# Altium schematic sheet HAC-NBC-PV1.0.SchDoc
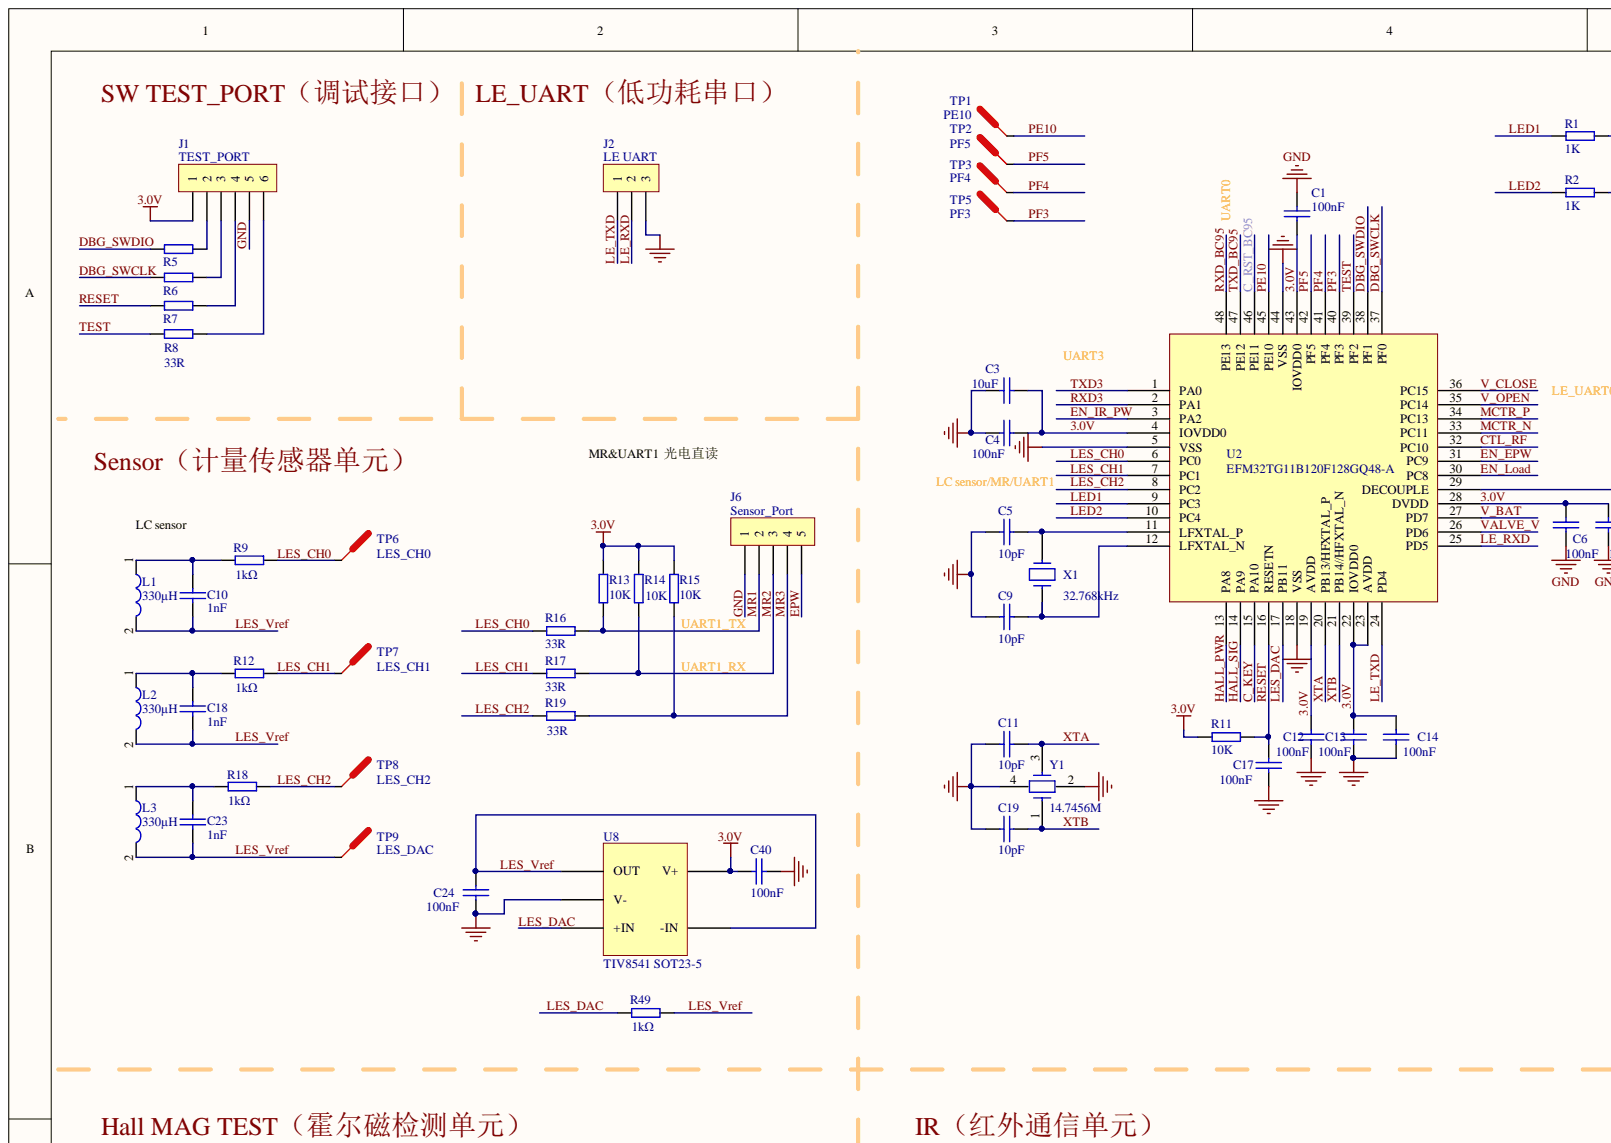
[diagram p0: HAC-NBC-PV1.0.SchDoc, top left]
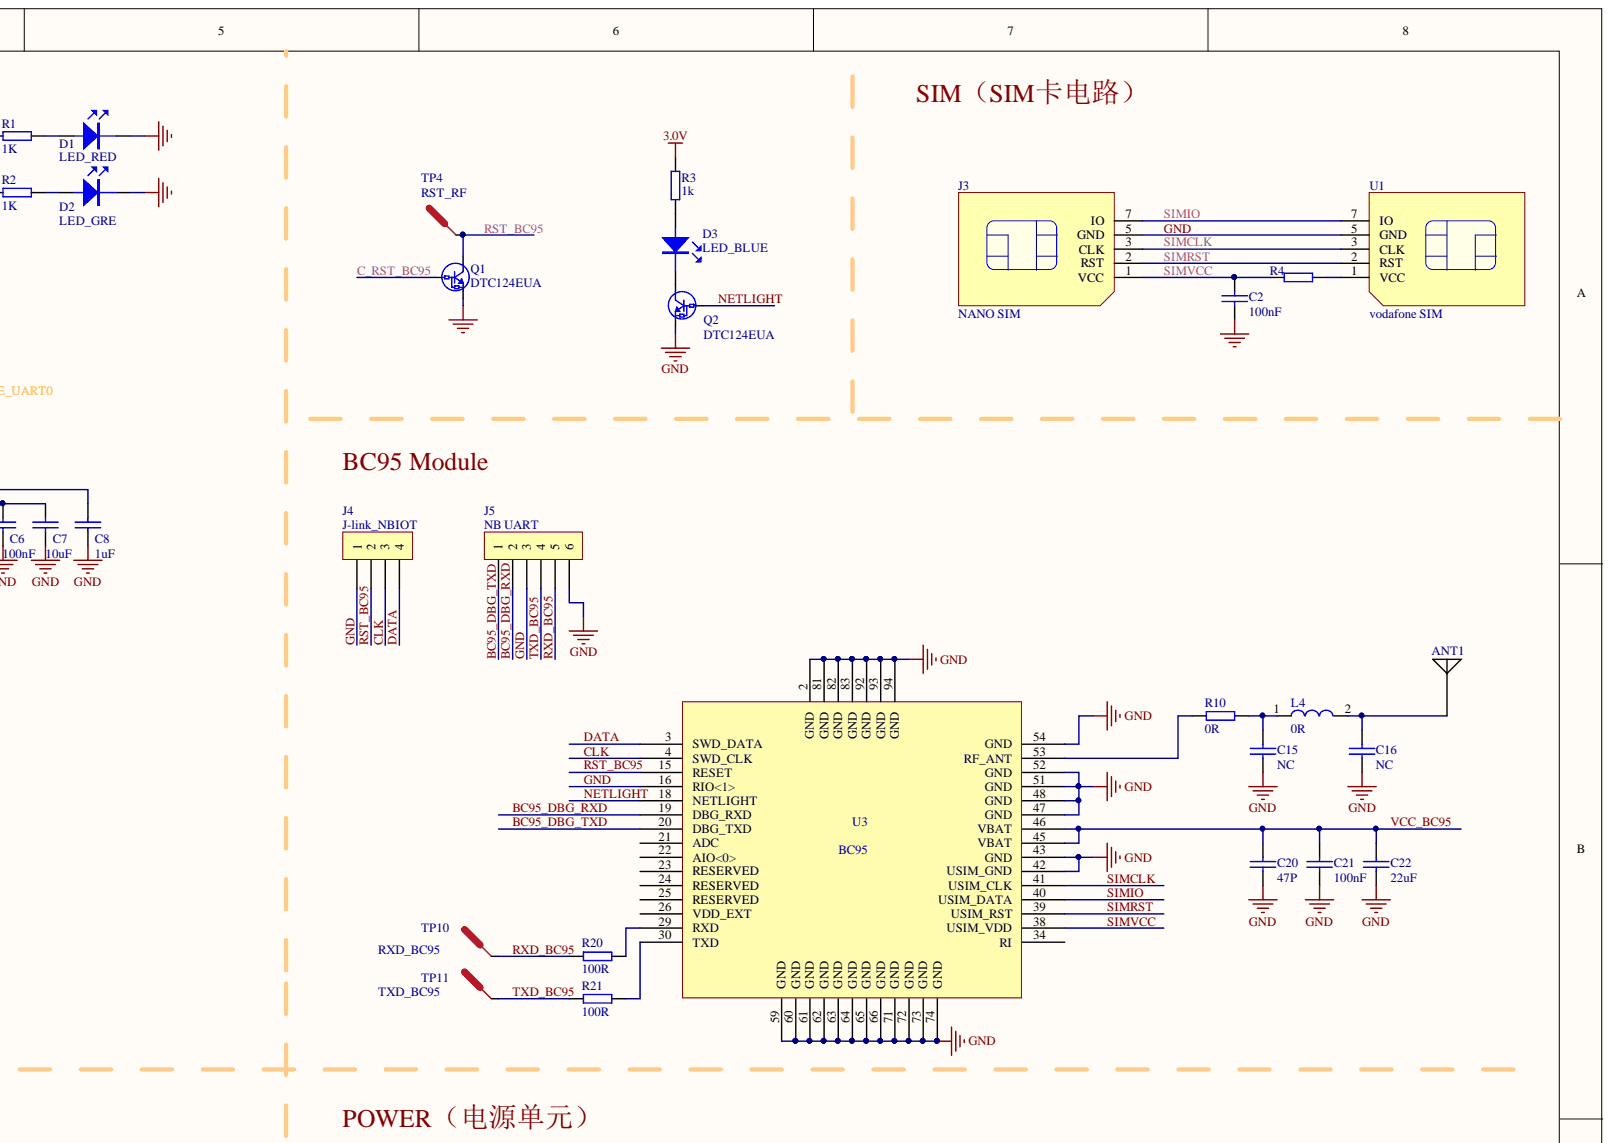
[diagram p1: HAC-NBC-PV1.0.SchDoc, top right]
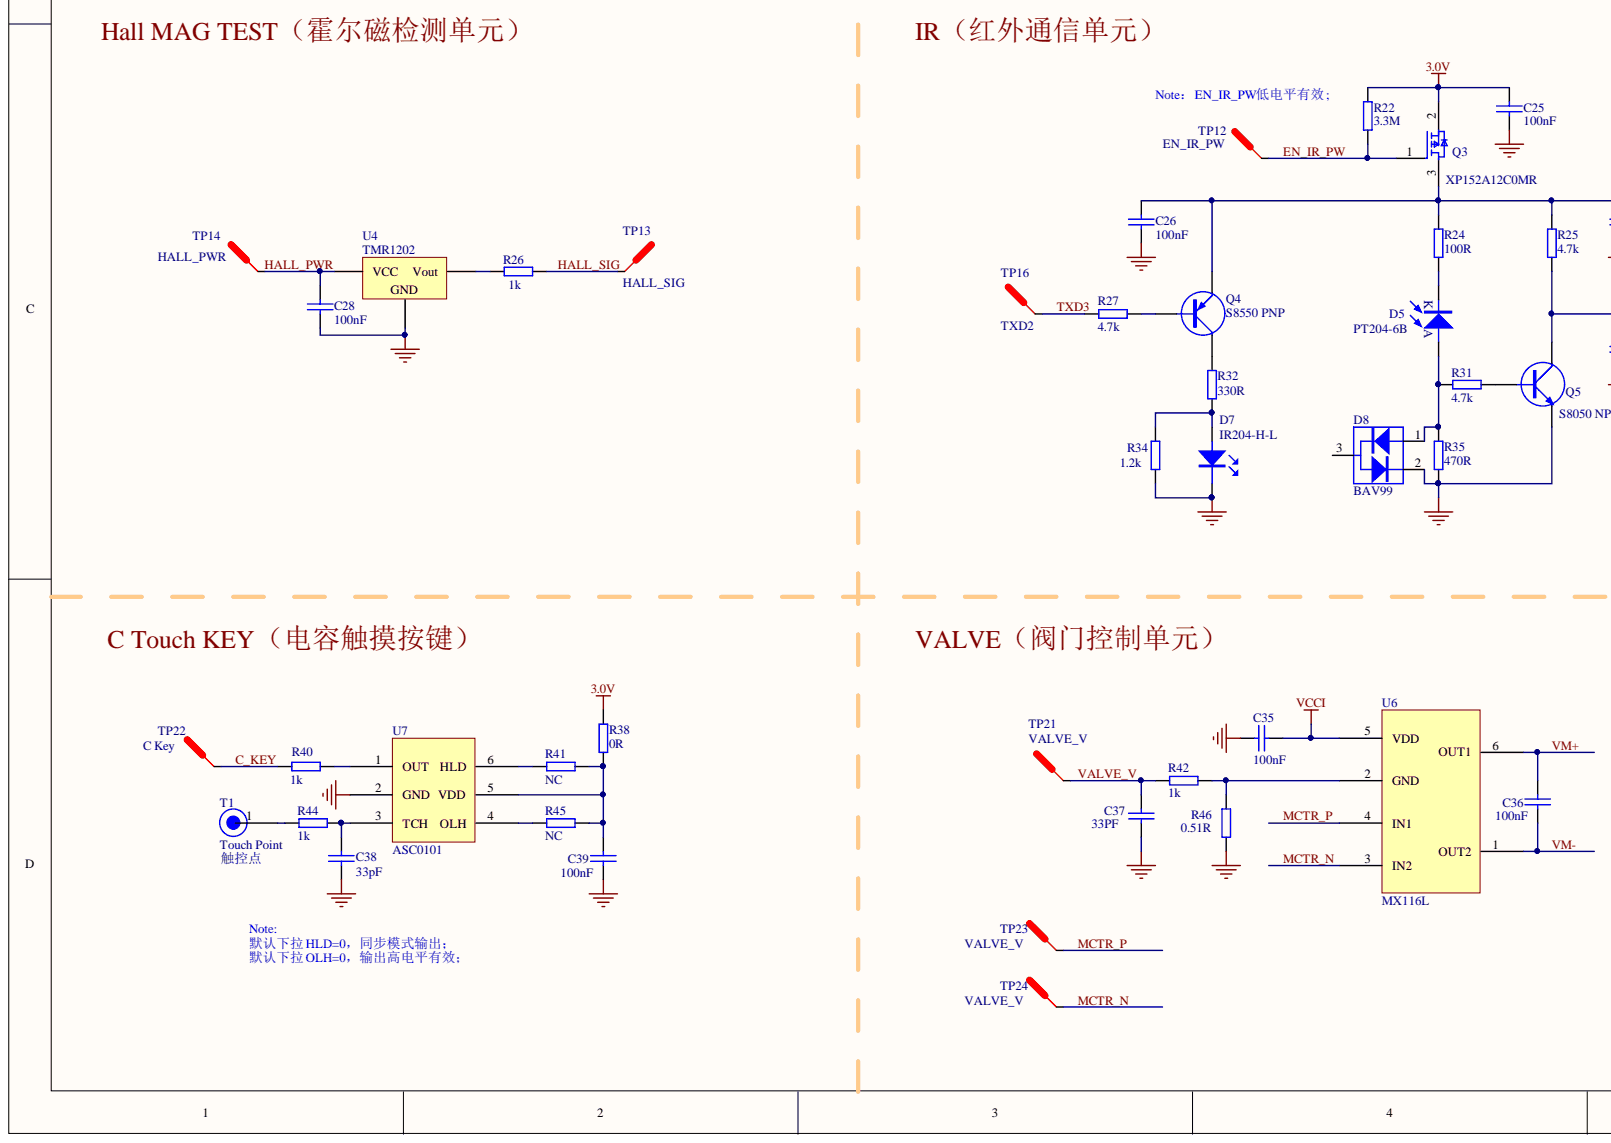
[diagram p2: HAC-NBC-PV1.0.SchDoc, bottom left]
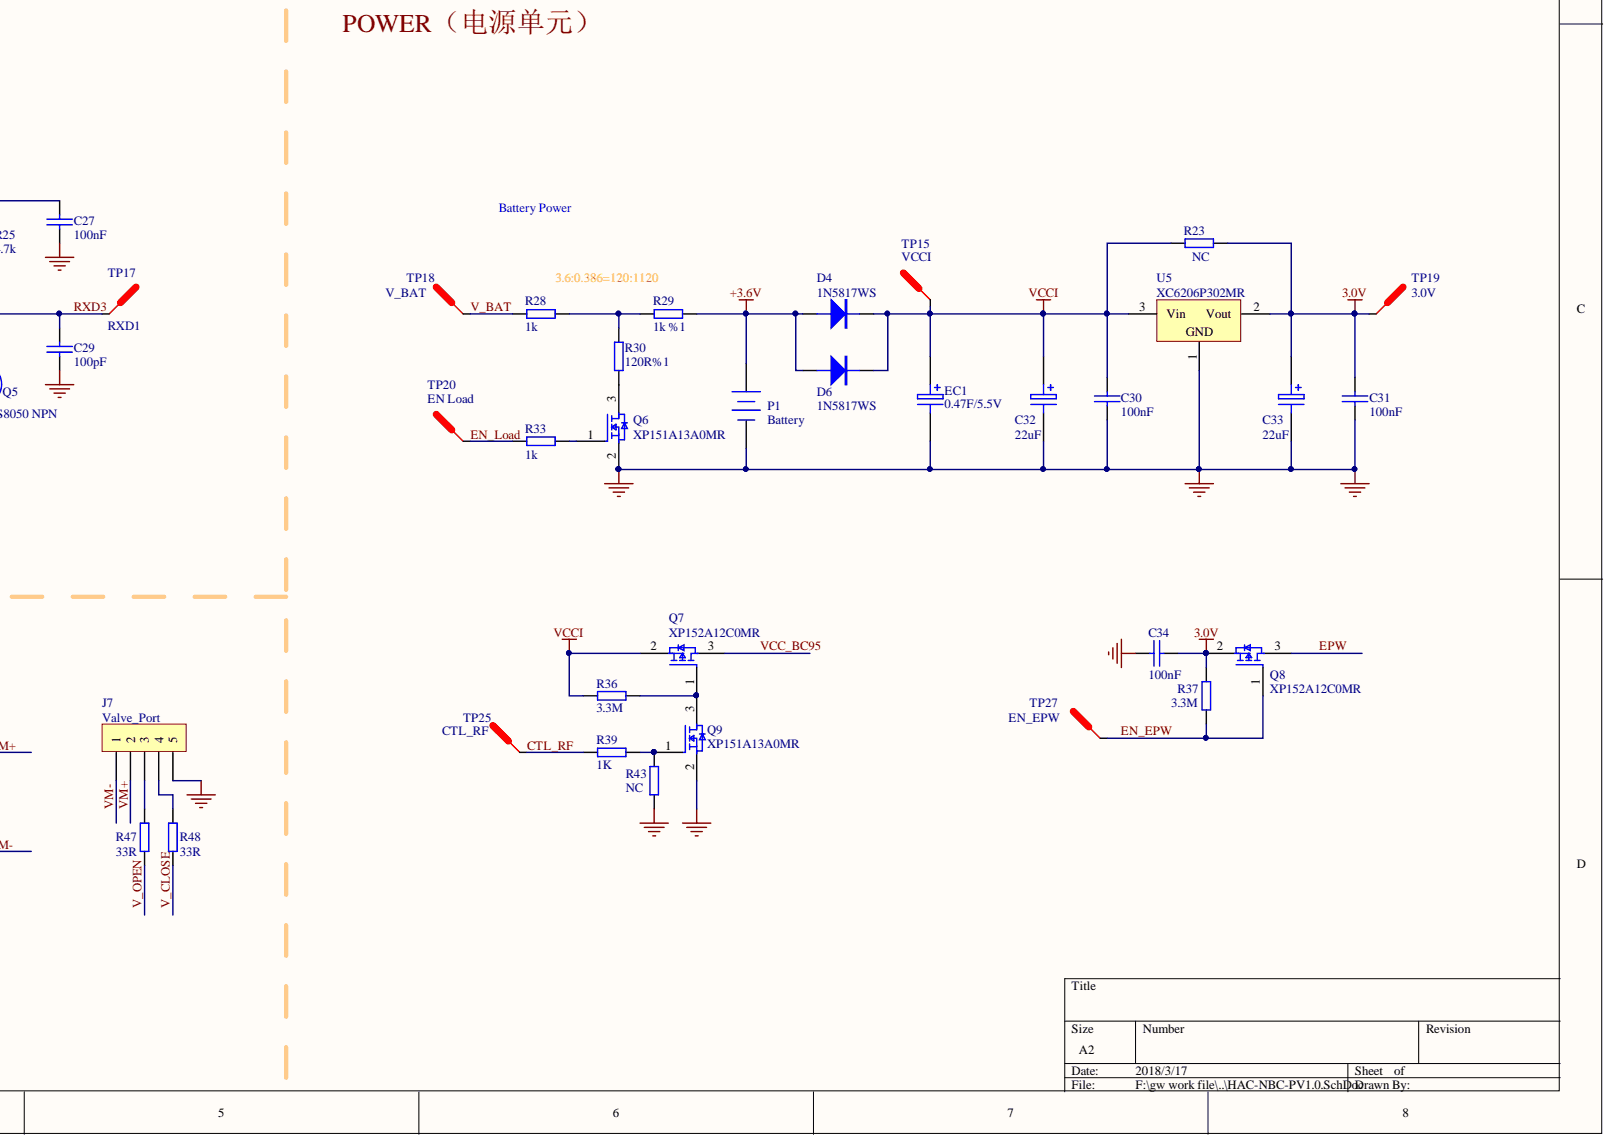
[diagram p3: HAC-NBC-PV1.0.SchDoc, bottom right]

## Parts
ANT1  Ant_PHT  [ANT-E]  (value not drawn)
C1  100nF  [0402-C]
C2  100nF  [0402-C]
C3  10uF  [0805-C]
C4  100nF  [0402-C]
C5  10pF  [0402-C]
C6  100nF  [0402-C]
C7  10uF  [0805-C]
C8  1uF  [0402-C]
C9  10pF  [0402-C]
C10  1nF  [0603-C]
C11  10pF  [0402-C]
C12  100nF  [0402-C]
C13  100nF  [0402-C]
C14  100nF  [0402-C]
C15  NC  [0402-C]
C16  NC  [0402-C]
C17  100nF  [0402-C]
C18  1nF  [0603-C]
C19  10pF  [0402-C]
C20  47P  [0402-C]
C21  100nF  [0402-C]
C22  22uF  [3216-C]
C23  1nF  [0603-C]
C24  100nF  [0603-C]
C25  100nF  [0402-C]
C26  100nF  [0402-C]
C27  100nF  [0402-C]
C28  100nF  [0402-C]
C29  100pF  [0402-C]
C30  100nF  [0402-C]
C31  100nF  [0402-C]
C32  22uF  [3216-C]
C33  22uF  [3216-C]
C34  100nF  [0402-C]
C35  100nF  [0402-C]
C36  100nF  [C0402-M]
C37  33PF  [0402-C]
C38  33pF  [0402-C]
C39  100nF  [0402-C]
C40  100nF  [0603-C]
D1  LED_RED  [0805-LED]
D2  LED_GRE  [0805-LED]
D3  LED_BLUE  [0805-LED]
D4  1N5817WS  [SOD-323]
D5  PT204-6B  [PT204-6C]
D6  1N5817WS  [SOD-323]
D7  IR204-H-L  [IR204-H16]
D8  BAV99  [SOT23-M]
EC1  0.47F/5.5V  [SP-5R5-Z474]
J1  TEST_PORT  [SIP6-2.0]
J2  LE UART  [SIP3-2.54]
J3  NANO SIM  [nanosim卡座]
J4  J-link_NBIOT  [SIP4-2.0]
J5  NB UART  [SIP6-2.0]
J6  Sensor_Port  [SIP5-2.54]
J7  Valve_Port  [SIP5-2.54]
L1  330μH  [工字型电感330μH]
L2  330μH  [工字型电感330μH]
L3  330μH  [工字型电感330μH]
L4  0R  [0402-L]
P1  Battery  [SIP2-2.54]
Q1  DTC124EUA  [DTC124EUA]
Q2  DTC124EUA  [DTC124EUA]
Q3  XP152A12C0MR  [SOT23-M]
Q4  S8550 PNP  [SOT23-M]
Q5  S8050 NPN  [SOT23-M]
Q6  XP151A13A0MR  [SOT23-M]
Q7  XP152A12C0MR  [SOT23-M]
Q8  XP152A12C0MR  [SOT23-M]
Q9  XP151A13A0MR  [SOT23-M]
R1  1K  [0402-R]
R2  1K  [0402-R]
R3  1k  [0402-R]
R4  0R  [0402-R]  (value not drawn)
R5  33R  [0402-M]  (value not drawn)
R6  33R  [0402-M]  (value not drawn)
R7  33R  [0402-M]
R8  33R  [0402-M]
R9  1kΩ  [0402-R]
R10  0R  [0402-R]
R11  10K  [0402-R]
R12  1kΩ  [0402-R]
R13  10K  [0402-R]
R14  10K  [0402-R]
R15  10K  [0402-R]
R16  33R  [0402-R]
R17  33R  [0402-R]
R18  1kΩ  [0402-R]
R19  33R  [0402-R]
R20  100R  [0402-R]
R21  100R  [0402-R]
R22  3.3M  [0402-R]
R23  NC  [0603-R]
R24  100R  [0402-R]
R25  4.7k  [0402-R]
R26  1k  [0402-R]
R27  4.7k  [0402-R]
R28  1k  [0402-R]
R29  1k %1  [0402-R]
R30  120R%1  [0402-R]
R31  4.7k  [0402-R]
R32  330R  [0402-R]
R33  1k  [0402-R]
R34  1.2k  [0402-R]
R35  470R  [0402-R]
R36  3.3M  [0402-R]
R37  3.3M  [0402-R]
R38  0R  [0402-R]
R39  1K  [0402-R]
R40  1k  [0603-R]
R41  NC  [0402-R]
R42  1k  [0402-R]
R43  NC  [0402-R]
R44  1k  [0402-R]
R45  NC  [0402-R]
R46  0.51R  [1206-R]
R47  33R  [0402-R]
R48  33R  [0402-R]
R49  1kΩ  [0402-R]
T1  Touch Point  [Touch Point]
TP1  PE10  [TP]
TP2  PF5  [TP]
TP3  PF4  [TP]
TP4  RST_RF  [TP]
TP5  PF3  [TP]
TP6  LES_CH0  [TP]
TP7  LES_CH1  [TP]
TP8  LES_CH2  [TP]
TP9  LES_DAC  [TP]
TP10  RXD_BC95  [TP]
TP11  TXD_BC95  [TP]
TP12  EN_IR_PW  [TP]
TP13  HALL_SIG  [TP]
TP14  HALL_PWR  [TP]
TP15  VCCI  [TP]
TP16  TXD2  [TP]
TP17  RXD1  [TP]
TP18  V_BAT  [TP]
TP19  3.0V  [TP]
TP20  EN Load  [TP]
TP21  VALVE_V  [TP]
TP22  C Key  [TP]
TP23  VALVE_V  [TP]
TP24  VALVE_V  [TP]
TP25  CTL_RF  [TP]
TP27  EN_EPW  [TP]
U1  vodafone SIM  [PCB SIM]
U2  EFM32TG11B120F128GQ48-A  [LQFP-48]
U3  BC95  [BC95]
U4  TMR1202  [SOT23-M]
U5  XC6206P302MR  [SOT23-M]
U6  MX116L  [SOT23-6]
U7  ASC0101  [SOT23-6]
U8  TIV8541 SOT23-5  [SOT23-5]
X1  32.768kHz  [XTAL_7*2]
Y1  14.7456M  [3225-4P]

## Nets
+3.6V: D4.1 D6.1 P1.1 R29.1
VCCI: C30.1 C32.1 C35.2 D4.2 D6.2 EC1.1 Q7.2 R23.1 R36.2 TP15.TP U5.3 U6.5(VDD)
3.0V: C1.2 C3.2 C4.2 C6.1 C7.1 C12.1 C13.1 C14.1 C25.2 C31.1 C33.1 C34.1 C40.1 J1.1 Q3.2 Q8.2 R3.2 R11.2 R13.1 R14.1 R15.1 R22.2 R23.2 R37.1 R38.2 TP19.TP U2.4(IOVDD0) U2.19(AVDD) U2.22(IOVDD0) U2.23(AVDD) U2.28(DVDD) U2.43(IOVDD0) U5.2 U8.5(V+)
BC95_DBG_RXD: J5.2 U3.19(DBG_RXD)
BC95_DBG_TXD: J5.1 U3.20(DBG_TXD)
C_KEY: R40.2 TP22.TP U2.15(PA10)
C_RST_BC95: Q1.1 U2.46(PE11)
CLK: J4.3 U3.4(SWD_CLK)
CTL_RF: R39.2 TP25.TP U2.32(PC10)
DATA: J4.4 U3.3(SWD_DATA)
DBG_SWCLK: R6.2 U2.37(PF0)
DBG_SWDIO: R5.1 U2.38(PF1)
EN_EPW: Q8.1 R37.2 TP27.TP U2.31(PC9)
EN_IR_PW: Q3.1 R22.1 TP12.TP U2.3(PA2)
EN_LOAD: R33.1 TP20.TP U2.30(PC8)
EPW: J6.5 Q8.3
GND: C1.1 C2.1 C3.1 C4.1 C5.1 C6.2 C7.2 C8.2 C9.1 C11.2 C12.2 C13.2 C14.2 C15.1 C16.1 C17.2 C19.2 C20.2 C21.1 C22.2 C24.2 C25.1 C26.1 C27.1 C28.2 C29.1 C30.2 C31.2 C32.2 C33.2 C34.2 C35.1 C37.1 C38.2 C39.2 C40.2 D1.2 D2.2 D7.2 D8.2 EC1.2 J1.5 J2.3 J3.5(GND) J4.1 J5.3 J5.6 J6.1 J7.5 P1.2 Q1.2 Q2.2 Q5.2 Q6.2 Q9.2 R34.1 R35.2 R43.2 R46.1 U1.5(GND) U2.5(VSS) U2.18(VSS) U2.44(VSS) U3.2(GND) U3.16(RIO<1>) U3.42(USIM_GND) U3.43(GND) U3.47(GND) U3.48(GND) U3.51(GND) U3.52(GND) U3.54(GND) U3.59(GND) U3.60(GND) U3.61(GND) U3.62(GND) U3.63(GND) U3.64(GND) U3.65(GND) U3.66(GND) U3.71(GND) U3.72(GND) U3.73(GND) U3.74(GND) U3.81(GND) U3.82(GND) U3.83(GND) U3.92(GND) U3.93(GND) U3.94(GND) U4.3 U5.1 U7.2(GND) U8.2(V-) Y1.2 Y1.4
HALL_PWR: C28.1 TP14.TP U2.13(PA8) U4.1
HALL_SIG: R26.1 TP13.TP U2.14(PA9)
LE_RXD: J2.2 U2.25(PD5)
LE_TXD: J2.1 U2.24(PD4)
LED1: R1.1 U2.9(PC3)
LED2: R2.1 U2.10(PC4)
LES_CH0: R9.2 R16.1 TP6.TP U2.6(PC0)
LES_CH1: R12.2 R17.1 TP7.TP U2.7(PC1)
LES_CH2: R18.2 R19.1 TP8.TP U2.8(PC2)
LES_DAC: R49.1 U2.17(PB11) U8.3(+IN)
LES_VREF: C10.2 C18.2 C23.2 C24.1 L1.2 L2.2 L3.2 R49.2 TP9.TP U8.1(OUT) U8.4(-IN)
MCTR_N: TP24.TP U2.33(PC11) U6.3(IN2)
MCTR_P: TP23.TP U2.34(PC13) U6.4(IN1)
MR1: J6.2 R13.2 R16.2
MR2: J6.3 R14.2 R17.2
MR3: J6.4 R15.2 R19.2
NETLIGHT: Q2.1 U3.18(NETLIGHT)
PE10: TP1.TP U2.45(PE10)
PF3: TP5.TP U2.40(PF3)
PF4: TP3.TP U2.41(PF4)
PF5: TP2.TP U2.42(PF5)
RESET: C17.1 R7.1 R11.1 U2.16(RESETN)
RST_BC95: J4.2 Q1.3 TP4.TP U3.15(RESET)
RXD3: C29.2 Q5.3 R25.1 TP17.TP U2.2(PA1)
RXD_BC95: J5.5 R20.1 TP10.TP U2.48(PE13)
SIMCLK: J3.3(CLK) U1.3(CLK) U3.41(USIM_CLK)
SIMIO: J3.7(IO) U1.7(IO) U3.40(USIM_DATA)
SIMRST: J3.2(RST) U1.2(RST) U3.39(USIM_RST)
SIMVCC: C2.2 J3.1(VCC) R4.1 U3.38(USIM_VDD)
TEST: R8.2 U2.39(PF2)
TXD3: R27.1 TP16.TP U2.1(PA0)
TXD_BC95: J5.4 R21.1 TP11.TP U2.47(PE12)
V_BAT: R28.1 TP18.TP U2.27(PD7)
V_CLOSE: R48.1 U2.36(PC15)
V_OPEN: R47.1 U2.35(PC14)
VALVE_V: C37.2 R42.2 TP21.TP U2.26(PD6)
VCC_BC95: C20.1 C21.2 C22.1 Q7.3 U3.45(VBAT) U3.46(VBAT)
VM+: C36.1 J7.2 U6.6(OUT1)
VM-: C36.2 J7.1 U6.1(OUT2)
XTA: C11.1 U2.20(PB13/HFXTAL_P) Y1.3
XTB: C19.1 U2.21(PB14//HFXTAL_N) Y1.1
NetANT1_1: ANT1.1 C16.2 L4.2
NetC5_2: C5.2 U2.11(LFXTAL_P) X1.1
NetC8_1: C8.1 U2.29(DECOUPLE)
NetC9_2: C9.2 U2.12(LFXTAL_N) X1.2
NetC10_1: C10.1 L1.1 R9.1
NetC15_2: C15.2 L4.1 R10.1
NetC18_1: C18.1 L2.1 R12.1
NetC23_1: C23.1 L3.1 R18.1
NetC26_2: C26.2 C27.2 Q3.3 Q4.2 R24.2 R25.2
NetC38_1: C38.1 R44.1 U7.3(TCH)
NetC39_1: C39.1 R38.1 R41.2 R45.2 U7.5(VDD)
NetD1_1: D1.1 R1.2
NetD2_1: D2.1 R2.2
NetD3_1: D3.1 R3.1
NetD3_2: D3.2 Q2.3
NetD5_1: D5.1 D8.1 R31.2 R35.1
NetD5_2: D5.2 R24.1
NetD7_1: D7.1 R32.2 R34.2
NetJ1_2: J1.2 R5.2
NetJ1_3: J1.3 R6.1
NetJ1_4: J1.4 R7.2
NetJ1_6: J1.6 R8.1
NetJ7_3: J7.3 R47.2
NetJ7_4: J7.4 R48.2
NetQ4_1: Q4.1 R27.2
NetQ4_3: Q4.3 R32.1
NetQ5_1: Q5.1 R31.1
NetQ6_1: Q6.1 R33.2
NetQ6_3: Q6.3 R30.2
NetQ7_1: Q7.1 Q9.3 R36.1
NetQ9_1: Q9.1 R39.1 R43.1
NetR4_2: R4.2 U1.1(VCC)
NetR10_2: R10.2 U3.53(RF_ANT)
NetR20_2: R20.2 U3.29(RXD)
NetR21_2: R21.2 U3.30(TXD)
NetR26_2: R26.2 U4.2
NetR28_2: R28.2 R29.2 R30.1
NetR40_1: R40.1 U7.1(OUT)
NetR41_1: R41.1 U7.6(HLD)
NetR42_1: R42.1 R46.2 U6.2(GND)
NetR44_2: R44.2 T1.1
NetR45_1: R45.1 U7.4(OLH)

Not connected: D8 3; U3 21(ADC) 22(AIO<0>) 23(RESERVED) 24(RESERVED) 25(RESERVED) 26(VDD_EXT) 34(RI)

## Library data of the parts
C1-C10 C12-C14 C17 C18 C20-C24 C30 C31 C34 C37 C38 C40 = CAP: Capacitor; Component Kind: Standard
C11 C25-C29 C35 C36 C39 = CAP: TDK电容 贴片ROHS&REACH 0402 18PF ±5%PF 50V COG TDK C1005C0G1H180J; Component Kind: Standard
C15 C16 = CAP: TDK电容 贴片ROHS&REACH 0402  5PF ±0.25PF 50V COG TDK C1005C0G1H050C; Component Kind: Standard
C19 = CAP: TDK电容 贴片ROHS&REACH 0402 15PF ±5%PF 50V COG TDK C1005C0G1H150J; Component Kind: Standard
C32 C33 EC1 = ELECTRO1: Electrolytic Capacitor; Component Kind: Standard
D1-D3 D7 = LED: Component Kind: Standard
D4 D6 = DIODE: Component Kind: Standard; Library Name: Schlib_Com.SCHLIB
D5 = PD15-22C-TR8: Component Kind: Standard; Library Name: SCH KU.SchLib
D8 = BAV99 SOT-23: Component Kind: Standard; Library Name: SCH KU.SchLib
J1 J5 = SIP6*2.0: Component Kind: Standard; Library Name: SCH KU.SchLib
J2 = SPI3*2.54: Component Kind: Standard; Library Name: SCH KU.SchLib
J3 = vodafone SIM: Component Kind: Standard; Library Name: Schlib_IC.SchLib
J4 = SIP4*2.54: Component Kind: Standard; Library Name: SCH KU.SchLib
J6 J7 = CON5: Connector; Component Kind: Standard
L1-L4 = INDUCTOR1: 电感 贴片ROHS&REACH 0402 12NH ±5%  太阳诱电 0402-12NH; Component Kind: Standard
P1 = Battery: Multicell Battery; Component Kind: Standard; LatestRevisionDate: 17-Jul-2002; LatestRevisionNote: Re-released for DXP Platform.; Library Name: Miscellaneous Devices.IntLib; PackageDescription: Battery; 2 Leads; PackageReference: BAT-2; Published: 24-Mar-1999; Publisher: Altium Limited
Q3 Q7 Q8 = BSH205: Component Kind: Standard
Q4 = BC857B PNP: Component Kind: Standard; Library Name: SCH KU.SchLib
Q5 = BC847B NPN: Component Kind: Standard; Library Name: SCH KU.SchLib
Q6 Q9 = BSS138: Component Kind: Standard
R1-R49 = RES2: Component Kind: Standard
TP1-TP25 TP27 = TP: Component Kind: Standard; Library Name: SCH KU.SchLib
U2 = EFM32TG11B120F128GQ48-A: QFP48; Component Kind: Standard; Library Name: SCH KU.SchLib
U3 = Component_1: Component Kind: Standard; Library Name: BC95.SchLib
U4 = Volt Reg: Component Kind: Standard; Description: TMR1202; LatestRevisionDate: 29-May-2009; LatestRevisionNote: IPC-7351 Footprint Added.; Library Name: Miscellaneous Devices.IntLib; PackageDescription: 3-Pin Transistor Outline Package 2.54 mm Pitch, 15.1 mm Lead Span; PackageReference: D2PAK; Published: 24-Mar-1999; Publisher: Altium Limited
U5 = XC6206P302MR SOT-23: SOT-23; Component Kind: Standard; Library Name: SCH KU.SchLib
U7 = ASC0101: 触控传感器; Component Kind: Standard; Library Name: Integrated_Library.IntLib
X1 = CRYSTAL: Component Kind: Standard; Description: Crystal
Y1 = XTAL_XXMHZ: 晶振;石英晶体  SMD 4pin  32MHz ±1 ppm 15pF; Component Kind: Standard
ANT1 = Ant_PHT: Component Kind: Standard; Library Name: HAC-N07D_PV2.0_2017-10-10.SCHLIB
Q1 Q2 = DTC124EUA: Component Kind: Standard
T1 = Touch Point: Component Kind: Standard; Library Name: SCH KU.SchLib
U1 = vodafone SIM: Component Kind: Standard; Library Name: Schlib_IC.SchLib
U6 = MX116L: Component Kind: Standard; Library Name: Integrated_Library.IntLib
U8 = TIV8541 SOT23-5: Component Kind: Standard; Library Name: SCH KU.SchLib
